# Altium schematic sheet [2]mcu.SchDoc([2]mcu)
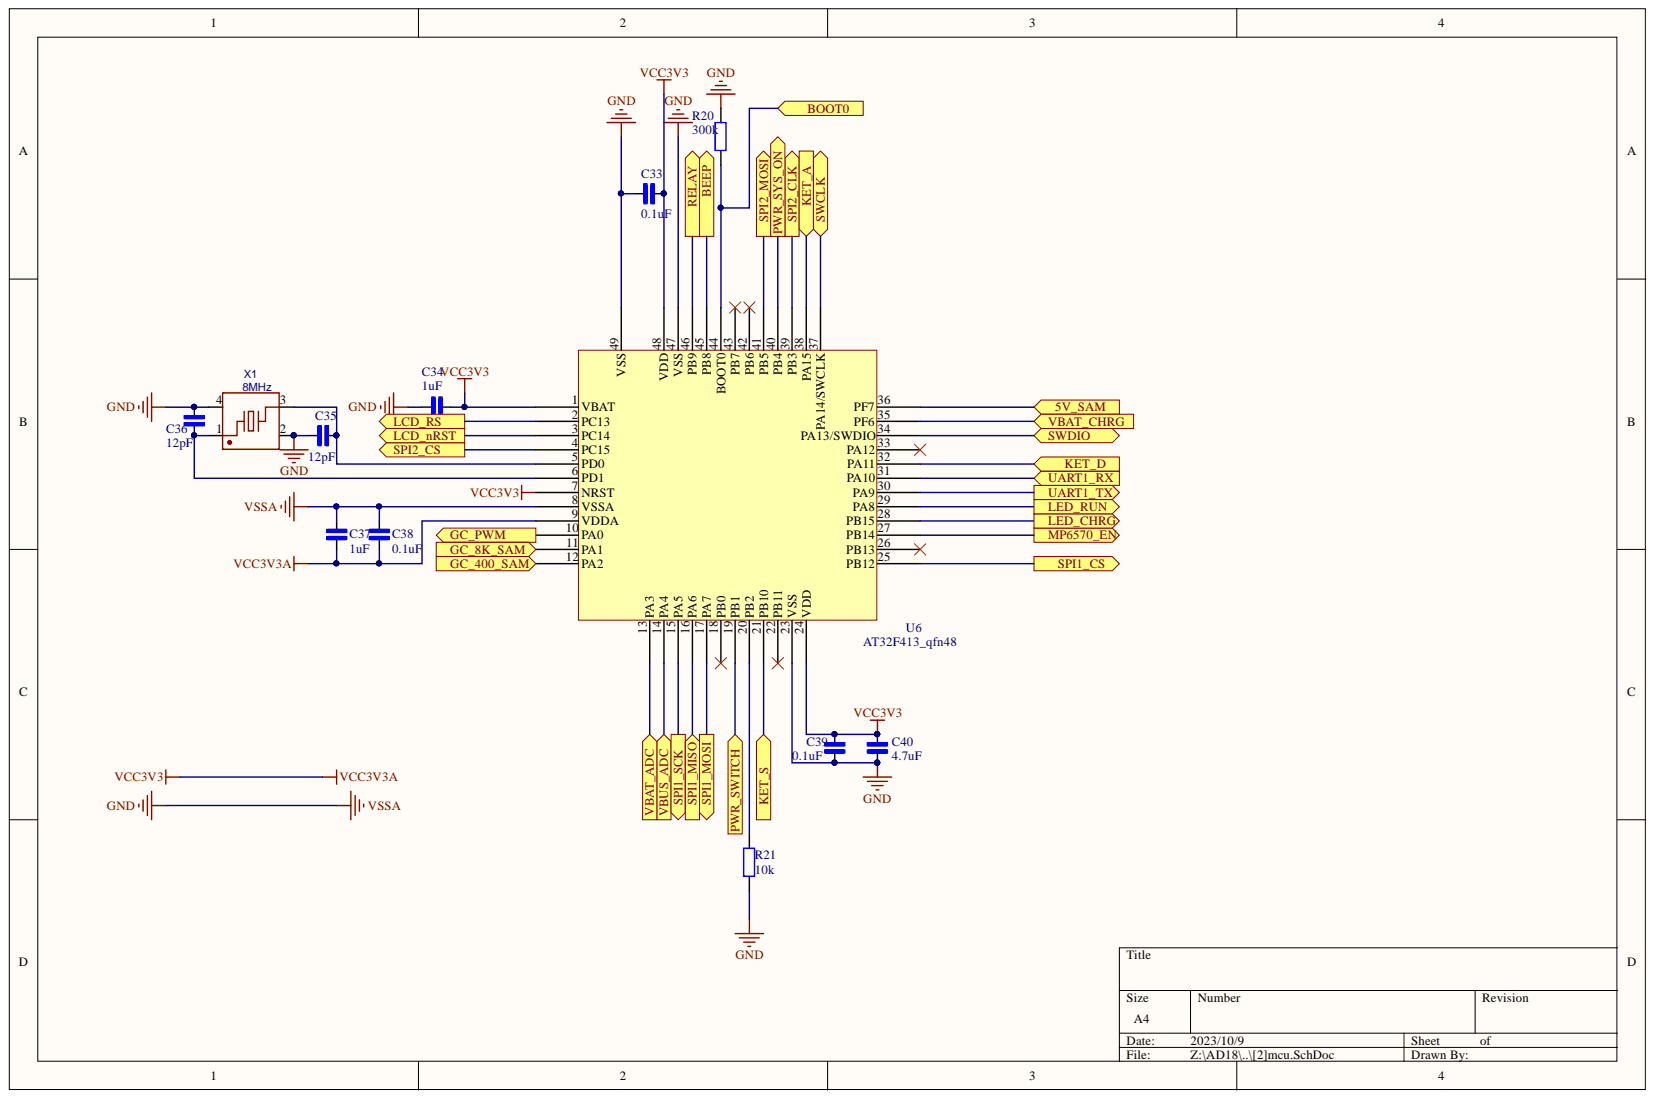
[diagram p0: [2]mcu.SchDoc]

## Parts
C33  0.1uF  [C0402]
C34  1uF  [C0402]
C35  12pF  [C0402]
C36  12pF  [C0402]
C37  1uF  [C0402]
C38  0.1uF  [C0402]
C39  0.1uF  [C0402]
C40  4.7uF  [C0603]
R20  300k  [R0402]
R21  10k  [R0402]
U6  AT32F413_qfn48  [AT32F415QFN48]
X1  8MHz  [X32258MOB4SI]

## Ports
5V_SAM, BEEP, BOOT0, GC_8K_SAM, GC_400_SAM, GC_PWM, KET_A, KET_D, KET_S, LCD_nRST, LCD_RS, LED_CHRG, LED_RUN, MP6570_EN, PWR_SWITCH, PWR_SYS_ON, RELAY, SPI1_CS, SPI1_MISO, SPI1_MOSI, SPI1_SCK, SPI2_CLK, SPI2_CS, SPI2_MOSI, SWCLK, SWDIO, UART1_RX, UART1_TX, VBAT_ADC, VBAT_CHRG, VBUS_ADC

## Nets
GND: C33.1 C34.2 C35.2 C36.1 C37.1 C38.1 C39.2 C40.2 R20.1 R21.2 U6.8(VSSA) U6.23(VSS) U6.47(VSS) U6.49(VSS) X1.2 X1.4
VCC3V3: C33.2 C34.1 C37.2 C38.2 C39.1 C40.1 U6.1(VBAT) U6.7(NRST) U6.9(VDDA) U6.24(VDD) U6.48(VDD)
5V_SAM: U6.36(PF7) ; port 5V_SAM
BEEP: U6.45(PB8) ; port BEEP
BOOT0: R20.2 U6.44(BOOT0) ; port BOOT0
GC_8K_SAM: U6.11(PA1) ; port GC_8K_SAM
GC_400_SAM: U6.12(PA2) ; port GC_400_SAM
GC_PWM: U6.10(PA0) ; port GC_PWM
KET_A: U6.38(PA15) ; port KET_A
KET_D: U6.32(PA11) ; port KET_D
KET_S: U6.21(PB10) ; port KET_S
LCD_nRST: U6.3(PC14) ; port LCD_nRST
LCD_RS: U6.2(PC13) ; port LCD_RS
LED_CHRG: U6.28(PB15) ; port LED_CHRG
LED_RUN: U6.29(PA8) ; port LED_RUN
MP6570_EN: U6.27(PB14) ; port MP6570_EN
PWR_SWITCH: U6.19(PB1) ; port PWR_SWITCH
PWR_SYS_ON: U6.40(PB4) ; port PWR_SYS_ON
RELAY: U6.46(PB9) ; port RELAY
SPI1_CS: U6.25(PB12) ; port SPI1_CS
SPI1_MISO: U6.16(PA6) ; port SPI1_MISO
SPI1_MOSI: U6.17(PA7) ; port SPI1_MOSI
SPI1_SCK: U6.15(PA5) ; port SPI1_SCK
SPI2_CLK: U6.39(PB3) ; port SPI2_CLK
SPI2_CS: U6.4(PC15) ; port SPI2_CS
SPI2_MOSI: U6.41(PB5) ; port SPI2_MOSI
SWCLK: U6.37(PA14/SWCLK) ; port SWCLK
SWDIO: U6.34(PA13/SWDIO) ; port SWDIO
UART1_RX: U6.31(PA10) ; port UART1_RX
UART1_TX: U6.30(PA9) ; port UART1_TX
VBAT_ADC: U6.13(PA3) ; port VBAT_ADC
VBAT_CHRG: U6.35(PF6) ; port VBAT_CHRG
VBUS_ADC: U6.14(PA4) ; port VBUS_ADC
NetC35_1: C35.1 U6.5(PD0) X1.3
NetC36_2: C36.2 U6.6(PD1) X1.1
NetR21_1: R21.1 U6.20(PB2)

Not connected: U6 18(PB0) 22(PB11) 26(PB13) 33(PA12) 42(PB6) 43(PB7)

## Library data of the parts
C33 C35 C36 C38 C39 = CAP_NPOL: 贴片电容 10%  10V; Library Name: MB1297 revC.IntLib; Component Kind: Standard
C34 C37 = CAP_NPOL: 贴片电容 10%  16V; Library Name: MB1297 revC.IntLib; Component Kind: Standard
C40 = CAP_NPOL: 贴片电容 10%  25V; Library Name: MB1297 revC.IntLib; Component Kind: Standard
R20 R21 = 0603 49.9KΩ: 贴片电阻 1% 1/16W 100ppm; ManufacturerNumber: RC0402FR-072K4L; Manufacturer: YAGEO; SuppliersPartNumber: C112296; Library Name: RES.SchLib; Component Kind: Standard
X1 = 8MHz: BOM_Manufacturer: YXC扬兴科技; nameAlias: Frequency; Contributor: LCSC; spiceSymbolName: X32258MOB4SI; spicePre: X; Component Kind: Standard; BOM_Supplier Part: C2682775; BOM_Supplier: LCSC; BOM_Manufacturer Part: X32258MOB4SI; BOM_JLCPCB Part Class: Extended Part
U6 = AT32F413_qfn48: Component Kind: Standard; Library Name: Green plum pro-04.SCHLIB
